# Altium schematic sheet Power.SchDoc(Power)
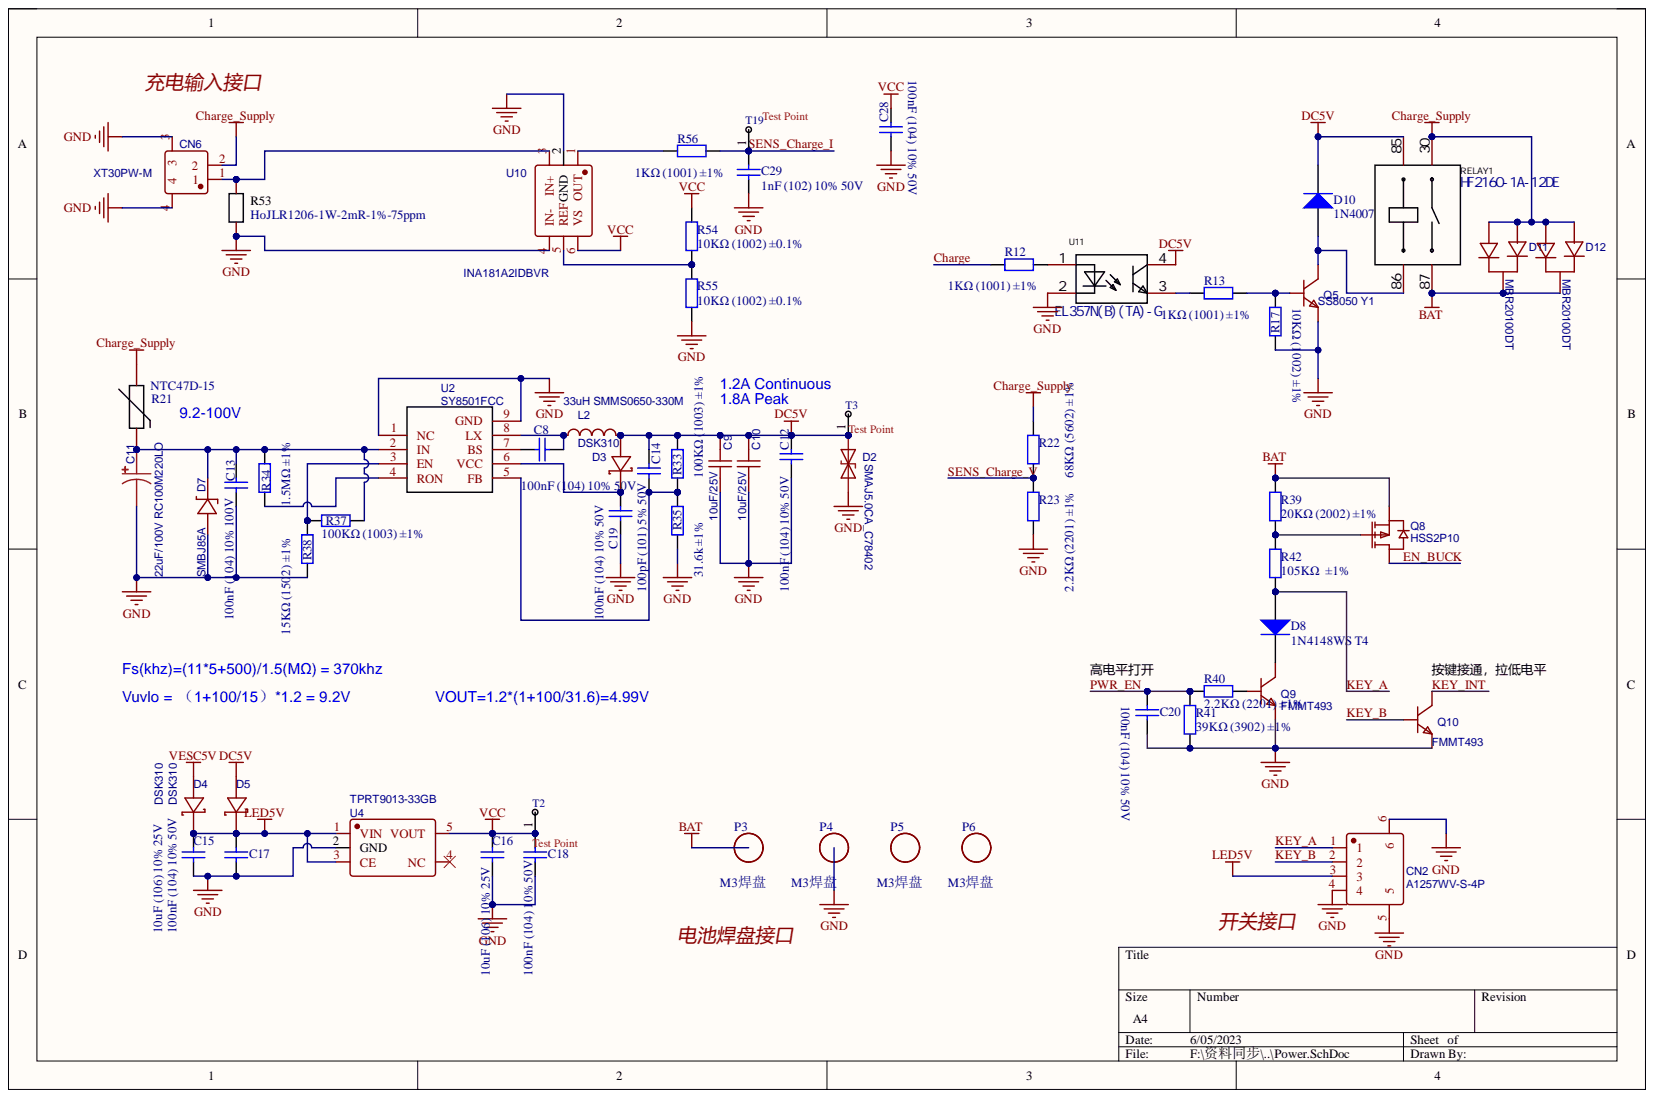
[diagram p0: Power.SchDoc]

## Parts
C8  100nF (104) 10% 50V  [0402]
C9  10uF/25V  [0805]
C10  10uF/25V  [0805]
C11  22uF/100V RC100M220LO  [CAP-TH_BD6.3-P2.50-D1.0-FD]
C12  100nF (104) 10% 50V  [0402]
C13  100nF (104) 10% 100V  [0603]
C14  100pF (101) 5% 50V  [0402]
C15  10uF (106) 10% 25V  [0603]
C16  10uF (106) 10% 25V  [0603]
C17  100nF (104) 10% 50V  [0402]
C18  100nF (104) 10% 50V  [0402]
C19  100nF (104) 10% 50V  [0402]
C20  100nF (104) 10% 50V  [0402]
C28  100nF (104) 10% 50V  [0402]
C29  1nF (102) 10% 50V  [0402]
CN2  A1257WV-S-4P  [CONN-SMD_A1257WV-S-4P]
CN6  XT30PW-M  [CONN-TH_XT30PW-M]
D2  SMAJ5.0CA_C78402  [SMA_L4.4-W2.6-LS5.0-BI]
D3  DSK310  [SOD-123_L2.7-W1.8-LS3.7-RD]
D4  DSK310  [SOD-123_L2.7-W1.8-LS3.7-RD]
D5  DSK310  [SOD-123_L2.7-W1.8-LS3.7-RD]
D7  SMBJ85A  [SMB_L4.4-W3.6-LS5.3-L-FD]
D8  1N4148WS T4  [SOD-323]
D10  1N4007  [SMA(DO-214AC)_S1]
D11  MBR20100DT  [PG-TO252-3_L6.5-W6.2-P4.57-LS10.0-BR-CW]
D12  MBR20100DT  [PG-TO252-3_L6.5-W6.2-P4.57-LS10.0-BR-CW]
L2  33uH SMMS0650-330M  [IND-SMD_L7.3-W6.6_YSPI07XX]
P3  M3焊盘  [PAD-M3]
P4  M3焊盘  [PAD-M3]
P5  M3焊盘  [PAD-M3]
P6  M3焊盘  [PAD-M3]
Q5  SS8050 Y1  [SOT-23-3_L2.9-W1.3-P1.90-LS2.4-BR]
Q8  HSS2P10  [SOT-23-3_L2.9-W1.6-P1.90-LS2.8-BR]
Q9  FMMT493  [SOT-23-3_L3.0-W1.7-P0.95-LS2.9-BR]
Q10  FMMT493  [SOT-23-3_L3.0-W1.7-P0.95-LS2.9-BR]
R12  1KΩ (1001) ±1%  [0603_R - No Top Overlayer]
R13  1KΩ (1001) ±1%  [0603_R - No Top Overlayer]
R17  10KΩ (1002) ±1%  [0603_R - No Top Overlayer]
R21  NTC47D-15  [RES-TH_L9.0-W4.7-P5.00-D1.2-S1.70]
R22  68KΩ (5602) ±1%  [0402]
R23  2.2KΩ (2201) ±1%  [0402]
R33  100KΩ (1003) ±1%  [0402]
R34  1.5MΩ ±1%  [0402]
R35  31.6k ±1%  [0402]
R37  100KΩ (1003) ±1%  [0402]
R38  15KΩ (1502) ±1%  [0402]
R39  20KΩ (2002) ±1%  [0402]
R40  2.2KΩ (2201) ±1%  [0402]
R41  39KΩ (3902) ±1%  [0402]
R42  105KΩ  ±1%  [0402]
R53  HoJLR1206-1W-2mR-1%-75ppm  [RES-SMD_L6.4-W3.2-1]
R54  10KΩ (1002) ±0.1%  [0402]
R55  10KΩ (1002) ±0.1%  [0402]
R56  1KΩ (1001) ±1%  [0402]
RELAY1  HF2160-1A-12DE  [YKWJD2912]
T2  Test Point  [TESTPOINT_1MM - small]
T3  Test Point  [TESTPOINT_1MM - small]
T19  Test Point  [TESTPOINT_1MM - PAD]
U2  SY8501FCC  [SOIC-8_L4.9-W3.9-P1.27-LS6.0-BL-EP]
U4  TPRT9013-33GB  [SOT-23-5_L3.0-W1.7-P0.95-LS2.8-BL]
U10  INA181A2IDBVR  [SOT-23-6_L2.9-W1.6-P0.95-LS2.8-TL]
U11  EL357N(B)(TA)-G  [OPTO-SMD-4_L4.4-W4.1-P2.54-LS7.0-TL]

## Nets
BAT: D11.2 D12.2 P3.1 Q8.2 R39.1 RELAY1.87
Charge_Supply: CN6.2 D11.1 D11.3 D12.1 D12.3 R21.2 R22.2 RELAY1.30
DC5V: C9.2 C10.2 C12.1 C14.2 D2.1 D3.2 D5.2 D10.2 L2.2 R33.2 RELAY1.85 T3.1 U11.4
GND: C9.1 C10.1 C11.2 C12.2 C13.1 C15.1 C16.1 C17.1 C18.1 C19.2 C20.1 C28.1 C29.1 CN2.4 CN2.5 CN2.6 CN6.3 CN6.4 D2.2 D7.1 P4.1 Q5.2 Q9.2 Q10.2 R17.2 R23.1 R35.1 R38.1 R41.2 R53.1 R55.1 U2.1(NC) U2.9(GND) U4.2(GND) U10.2(GND) U10.4(IN-) U11.2
LED5V: C15.2 C17.2 CN2.3 D4.1 D5.1 U4.1(VIN) U4.3(CE)
VCC: C16.2 C18.2 C28.2 R54.2 T2.1 U4.5(VOUT) U10.6(VS)
VESC5V: D4.2
Charge: R12.2
EN_BUCK: Q8.3
KEY_A: CN2.1 D8.1 R42.2
KEY_B: CN2.2 Q10.1
KEY_INT: Q10.3
PWR_EN: C20.2 R40.2 R41.1
SENS_Charge_I: C29.2 R56.2 T19.1
SENS_Charge_V: R22.1 R23.2
NetC8_1: C8.1 U2.7(BS)
NetC8_2: C8.2 L2.1 U2.8(LX)
NetC11_1: C11.1 C13.2 D7.2 R21.1 R34.2 R37.2 U2.2(IN)
NetC14_1: C14.1 R33.1 R35.2 U2.5(FB)
NetC19_1: C19.1 D3.1 U2.6(VCC)
NetCN6_1: CN6.1 R53.2 U10.3(IN+)
NetD8_2: D8.2 Q9.3
NetD10_1: D10.1 Q5.3 RELAY1.86
NetQ5_1: Q5.1 R13.2 R17.1
NetQ8_1: Q8.1 R39.2 R42.1
NetQ9_1: Q9.1 R40.1
NetR12_1: R12.1 U11.1
NetR13_1: R13.1 U11.3
NetR34_1: R34.1 U2.4(RON)
NetR37_1: R37.1 R38.2 U2.3(EN)
NetR54_1: R54.1 R55.2 U10.5(REF)
NetR56_1: R56.1 U10.1(OUT)

Not connected: P5 1; P6 1; U4 4(NC)

## Library data of the parts
C8 C12 C13 C17-C20 = 0603 100nF (104) 10% 50V: 贴片电容; Component Kind: Standard; ComponentLink1Description: 供应商链接; ComponentLink1URL: http://www.szlcsc.com/product/details_15331.html; Package: 0603; Supplier: LC; SuppliersPartNumber: C14663
  C8 C12 C13 C17-C19: Library Name: Cap.SchLib
  C20: Library Name: Miscellaneous Devices LC.IntLib
C9 C10 = 22uF: BOM_Manufacturer: Samsung Electro-Mechanics; BOM_Manufacturer Part: CL21A226MAYNNNE; Contributor: LCSC; nameAlias: Capacitance; BOM_JLCPCB Part Class: Extended Part; BOM_Supplier: LCSC; BOM_Supplier Part: C602037; Component Kind: Standard; spicePre: C; spiceSymbolName: CL21A226MAYNNNE
C11 = 22uF: BOM_Manufacturer: BERYL Electronic Tech; BOM_Manufacturer Part: RC100M220LO6.3*11TH-2A1E; Contributor: LCSC; nameAlias: Capacitance; BOM_JLCPCB Part Class: Extended Part; BOM_Supplier: LCSC; BOM_Supplier Part: C365778; Component Kind: Standard; spicePre: C; spiceSymbolName: RC100M220LO6.3*11TH-2A1E
C14 = 0402 100pF (101) 5% 50V: 贴片电容; Component Kind: Standard; ComponentLink1Description: 供应商链接; ComponentLink1URL: http://www.szlcsc.com/product/details_1898.html; Library Name: Cap.SchLib; Package: 0402; Supplier: LC; SuppliersPartNumber: C1546
C15 C16 = 0805 10uF (106) 10% 25V: 贴片电容; Component Kind: Standard; ComponentLink1Description: 供应商链接; ComponentLink1URL: http://www.szlcsc.com/product/details_16532.html; Library Name: Cap.SchLib; Package: 0805; Supplier: LC; SuppliersPartNumber: C15850
C28 = 0402 100nF (104) 10% 16V: 贴片电容; Component Kind: Standard; ComponentLink1Description: 供应商链接; ComponentLink1URL: http://www.szlcsc.com/product/details_1877.html; Library Name: Cap.SchLib; Package: 0402; Supplier: LC; SuppliersPartNumber: C1525
C29 = 0402 1nF (102) 10% 50V: 贴片电容; Component Kind: Standard; ComponentLink1Description: 供应商链接; ComponentLink1URL: http://www.szlcsc.com/product/details_1875.html; Library Name: Cap.SchLib; Package: 0402; Supplier: LC; SuppliersPartNumber: C1523
CN2 = A1257WV-S-4P: BOM_Manufacturer: Changjiang Connectors; Contributor: LCSC; BOM_JLCPCB Part Class: Extended Part; BOM_Manufacturer Part: A1257WV-S-4P; BOM_Supplier: LCSC; BOM_Supplier Part: C225120; Component Kind: Standard; spicePre: C; spiceSymbolName: A1257WV-S-4P
CN6 = XT30PW-M: BOM_Manufacturer: Changzhou Amass Elec; Contributor: LCSC; BOM_JLCPCB Part Class: Extended Part; BOM_Manufacturer Part: XT30PW-M; BOM_Supplier: LCSC; BOM_Supplier Part: C431092; Component Kind: Standard; spicePre: C; spiceSymbolName: XT30PW-M
D2 = SMAJ5.0CA_C78402: BOM_Manufacturer: Brightking; BOM_JLCPCB Part Class: Extended Part; BOM_Manufacturer Part: SMAJ5.0CA; BOM_Supplier: LCSC; BOM_Supplier Part: C78402; Component Kind: Standard; spicePre: D; spiceSymbolName: SMAJ5.0CA_C78402
D3-D5 = DSK310: BOM_Manufacturer: MDD; Contributor: LCSC; BOM_JLCPCB Part Class: Extended Part; BOM_Manufacturer Part: DSK310; BOM_Supplier: LCSC; BOM_Supplier Part: C106914; Component Kind: Standard; spicePre: D; spiceSymbolName: DSK310
D7 = SMBJ85A: BOM_Manufacturer: Shandong Jingdao Microelectronics; Contributor: LCSC; BOM_JLCPCB Part Class: Extended Part; BOM_Manufacturer Part: SMBJ85A; BOM_Supplier: LCSC; BOM_Supplier Part: C353327; Component Kind: Standard; spicePre: D; spiceSymbolName: SMBJ85A
D8 D10 = SOD-323 1N4148WS T4: 二极管; Component Kind: Standard; ComponentLink1Description: 供应商链接; ComponentLink1URL: http://www.szlcsc.com/product/details_2485.html; Library Name: Miscellaneous Devices LC.IntLib; Package: SOD-323; Supplier: LC; SuppliersPartNumber: C2128
D11 D12 = MBR20100DT: BOM_Manufacturer: Shandong Jingdao Microelectronics; Contributor: LCSC; BOM_JLCPCB Part Class: Extended Part; BOM_Manufacturer Part: MBR20100DT; BOM_Supplier: LCSC; BOM_Supplier Part: C668178; Component Kind: Standard; spicePre: D; spiceSymbolName: MBR20100DT
L2 = 33uH: BOM_Manufacturer: YJYCOIN; BOM_Manufacturer Part: YSPI0740-330M; Contributor: LCSC; nameAlias: Inductance; BOM_JLCPCB Part Class: Extended Part; BOM_Supplier: LCSC; BOM_Supplier Part: C718398; Component Kind: Standard; spicePre: L; spiceSymbolName: YSPI0740-330M
P3-P6 = 贴片螺母: Component Kind: Standard; Library Name: SCH.SchLib
Q5 = S8050_C2894711: BOM_Manufacturer: PUOLOP(迪浦); BOM_Manufacturer Part: S8050; Contributor: LCSC; BOM_Supplier: LCSC; BOM_Supplier Part: C2894711; Component Kind: Standard; spicePre: Q; spiceSymbolName: S8050_C2894711
Q8 = HSS2P10: BOM_Manufacturer: HUASHUO; Contributor: LCSC; BOM_JLCPCB Part Class: Extended Part; BOM_Manufacturer Part: HSS2P10; BOM_Supplier: LCSC; BOM_Supplier Part: C845592; Component Kind: Standard; spicePre: Q; spiceSymbolName: HSS2P10
Q9 Q10 = FMMT493: BOM_Manufacturer: CJ; SMT Type: Extend; BOM_LCSC Assembly: Yes; BOM_Manufacturer Part: FMMT493; BOM_Supplier: LCSC; BOM_Supplier Part: C77886; Component Kind: Standard; image: //image.lceda.cn/szlcsc/C77886.jpg; spicePre: Q; spiceSymbolName: FMMT493
R12 R13 R56 = 0402 1KΩ (1001) 1%: 贴片电阻; Component Kind: Standard; ComponentLink1Description: 供应商链接; ComponentLink1URL: http://www.szlcsc.com/product/details_12256.html; Library Name: RES.SchLib; Package: 0402; Supplier: LC; SuppliersPartNumber: C11702
R17 R40 R54 R55 = 0603 10KΩ (1002) 1%: 贴片电阻; Component Kind: Standard; ComponentLink1Description: 供应商链接; ComponentLink1URL: http://www.szlcsc.com/product/details_26547.html; Package: 0603; Supplier: LC; SuppliersPartNumber: C25804
  R17 R40: Library Name: Miscellaneous Devices LC.IntLib
  R54 R55: Library Name: RES.SchLib
R21 = MF0705002M4BP0FPT0: Manufacturer: STE(松田); Add into BOM: yes; B Constant (25℃/50℃): 2600K; B Constant Tolerance: ±10%; Resistance @ 25℃: 5Ω; Resistance Tolerance: ±20%; Steady State Current (Max): 2A; B Constant (25℃/75℃): -; B Constant (25℃/85℃): -; B Constant (25℃/100℃): -; Component Kind: Standard; Convert to PCB: yes; Datasheet: https://atta.szlcsc.com/upload/public/pdf/source/20190213/C363404_170BB6CFC3C7385087074F92BC26D446.pdf; Device: MF0705002M4BP0FPT0; JLCPCB Part Class: Extended Part; LCSC Part Name: 5Ω 电阻精度:±20% 2600K; Manufacturer Part: MF0705002M4BP0FPT0; Name: MF0705002M4BP0FPT0; Operating Temperature: -40℃~+155℃; PCB3D: RES-TH_L9.0-W4.7-H12.0-P5.00-D0.6-S1.70; Power: -; Supplier: LCSC; Supplier Footprint: 插件,P=5mm; Supplier Part: C363404; Symbol: MF0705002M4BP0FPT0
R22 R41 = 0603 39KΩ (3902) 1%: 贴片电阻; Component Kind: Standard; ComponentLink1Description: 供应商链接; ComponentLink1URL: http://www.szlcsc.com/product/details_23880.html; Package: 0603; Supplier: LC; SuppliersPartNumber: C23153
  R22: Library Name: RES.SchLib
  R41: Library Name: Miscellaneous Devices LC.IntLib
R23 = 0603 2.2KΩ (2201) 1%: 贴片电阻; Component Kind: Standard; ComponentLink1Description: 供应商链接; ComponentLink1URL: http://www.szlcsc.com/product/details_4597.html; Library Name: RES.SchLib; Package: 0603; Supplier: LC; SuppliersPartNumber: C4190
R33 R37 R42 = 0402 100KΩ (1003) 1%: 贴片电阻; Component Kind: Standard; ComponentLink1Description: 供应商链接; ComponentLink1URL: http://www.szlcsc.com/product/details_26484.html; Library Name: RES.SchLib; Package: 0402; Supplier: LC; SuppliersPartNumber: C25741
R34 = 0402 1.5KΩ (1501) 1%: 贴片电阻; Component Kind: Standard; ComponentLink1Description: 供应商链接; ComponentLink1URL: http://www.szlcsc.com/product/details_26610.html; Library Name: RES.SchLib; Package: 0402; Supplier: LC; SuppliersPartNumber: C25867
R35 = 0402 39KΩ (3902) 1%: 贴片电阻; Component Kind: Standard; ComponentLink1Description: 供应商链接; ComponentLink1URL: http://www.szlcsc.com/product/details_26526.html; Library Name: RES.SchLib; Package: 0402; Supplier: LC; SuppliersPartNumber: C25783
R38 = 0402 15KΩ (1502) 1%: 贴片电阻; Component Kind: Standard; ComponentLink1Description: 供应商链接; ComponentLink1URL: http://www.szlcsc.com/product/details_26499.html; Library Name: RES.SchLib; Package: 0402; Supplier: LC; SuppliersPartNumber: C25756
R39 = 0402 20KΩ (2002) 1%: 贴片电阻; Component Kind: Standard; ComponentLink1Description: 供应商链接; ComponentLink1URL: http://www.szlcsc.com/product/details_26508.html; Library Name: RES.SchLib; Package: 0402; Supplier: LC; SuppliersPartNumber: C25765
R53 = HoJLR1206-1W-2mR-1%-75ppm: Manufacturer: Milliohm(毫欧); Add into BOM: yes; Name: 2mΩ; Power(Watts): 1W; Temperature Coefficient: ±75ppm/℃; Tolerance: ±1%; Value: 2mΩ; Component Kind: Standard; Convert to PCB: yes; Datasheet: https://atta.szlcsc.com/upload/public/pdf/source/20211018/BB383758CE5FFFC77D9F4A6B94AD2030.pdf; Device: HoJLR1206-1W-2mR-1%-75ppm; JLCPCB Part Class: Extended Part; LCSC Part Name: 2mΩ ±1% 1W; Manufacturer Part: HoJLR1206-1W-2mR-1%-75ppm; Operating Temperature Range: -55℃~+155℃; Overload Voltage (Max): -; PCB3D: R1206_L3.2-W1.6-H0.6; Supplier: LCSC; Supplier Footprint: 1206; Supplier Part: C2924496; Symbol: HOJLR1206-1W-2MR-1%-75PPM; Type: -
RELAY1 = YKWJD2912: Manufacturer: HF(宏发); Add into BOM: yes; Coil Rated Power: 900mW; Coil Resistance: 155Ω; Coil Type: 非极化-单线圈; Coil Voltage: 12V; Contact Form: 一组常开:1A(单刀单掷-常开); Contact Rating: 30A@240VAC；20A@30VDC; Contact Resistance: 50mΩ; Maximum Switching Current: 40A; Maximum Switching Voltage: 277V@AC；30V@DC; Operate Time: 15ms; Pin Number: 4; Release Time: 10ms; Component Kind: Standard; Contact Material: AgCdO; Convert to PCB: yes; Datasheet: https://atta.szlcsc.com/upload/public/pdf/source/20120518/1457706513510.pdf; Device: HF2160-1A-12DE; JLCPCB Part Class: Extended Part; LCSC Part Name: HF2160-1A-12DE; Manufacturer Part: HF2160-1A-12DE; Name: HF2160-1A-12DE; Operating Temperature: -55℃~+100℃; PCB3D: RELAY-TH_HF2160-1A-XX; Supplier: LCSC; Supplier Footprint: 插件,27.5x32.2mm; Supplier Part: C3628; Symbol: HF2160-1A-12DE; Library Name: LCM.SchLib
T2 T3 T19 = U: Component Kind: Standard
U2 = SY8502FCC: BOM_Manufacturer: Silergy; BOM_JLCPCB Part Class: Extended Part; BOM_Manufacturer Part: SY8502FCC; BOM_Supplier: LCSC; BOM_Supplier Part: C87752; Component Kind: Standard; spicePre: U; spiceSymbolName: SY8502FCC
U4 = TPRT9013-33GB: BOM_Manufacturer: TECH PUBLIC; Contributor: LCSC; BOM_JLCPCB Part Class: Extended Part; BOM_Manufacturer Part: TPRT9013-33GB; BOM_Supplier: LCSC; BOM_Supplier Part: C587158; Component Kind: Standard; spicePre: U; spiceSymbolName: TPRT9013-33GB
U10 = INA181A1IDBVR: BOM_Manufacturer: TI(德州仪器); Contributor: LCSC; BOM_JLCPCB Part Class: Extended Part; BOM_Manufacturer Part: INA181A1IDBVR; BOM_Supplier: LCSC; BOM_Supplier Part: C2058943; Component Kind: Standard; spicePre: U; spiceSymbolName: INA181A1IDBVR
U11 = EL357N(B)(TA)-G: Manufacturer: EVERLIGHT(亿光); Add into BOM: yes; Collector-Emitter Saturation Voltage (Vce(sat)@Ic,IF): 100mV@1mA,20mA; Fall time: 4us@2mA,100Ω; Forward Voltage: 1.2V; Input voltage type: DC; Isolation Voltage(rms): 3.75kV; Number of Outputs: 1; Output Current: 50mA; Output Type: 光电三极管; Receiving End Voltage: 80V; Reverse Voltage: 6V; Rise time: 3us@2mA,100Ω; Component Kind: Standard; Convert to PCB: yes; Datasheet: https://atta.szlcsc.com/upload/public/pdf/source/20120405/1457706466151.pdf; Device: EL357N(B)(TA)-G; JLCPCB Part Class: Basic Part; LCSC Part Name: EL357N(B)(TA)-G; Manufacturer Part: EL357N(B)(TA)-G; Name: EL357N(B)(TA)-G; Operating Temperature: -55℃~+110℃; PCB3D: OPTO-SMD-4P_L4.1-W4.4-H2.0-LS7.0-P2.54; Supplier: LCSC; Supplier Footprint: SOP-4-2.54; Supplier Part: C6649; Symbol: EL357N(B)(TA)-G
